# Altium schematic sheet LoRa.SchDoc(LoRa)
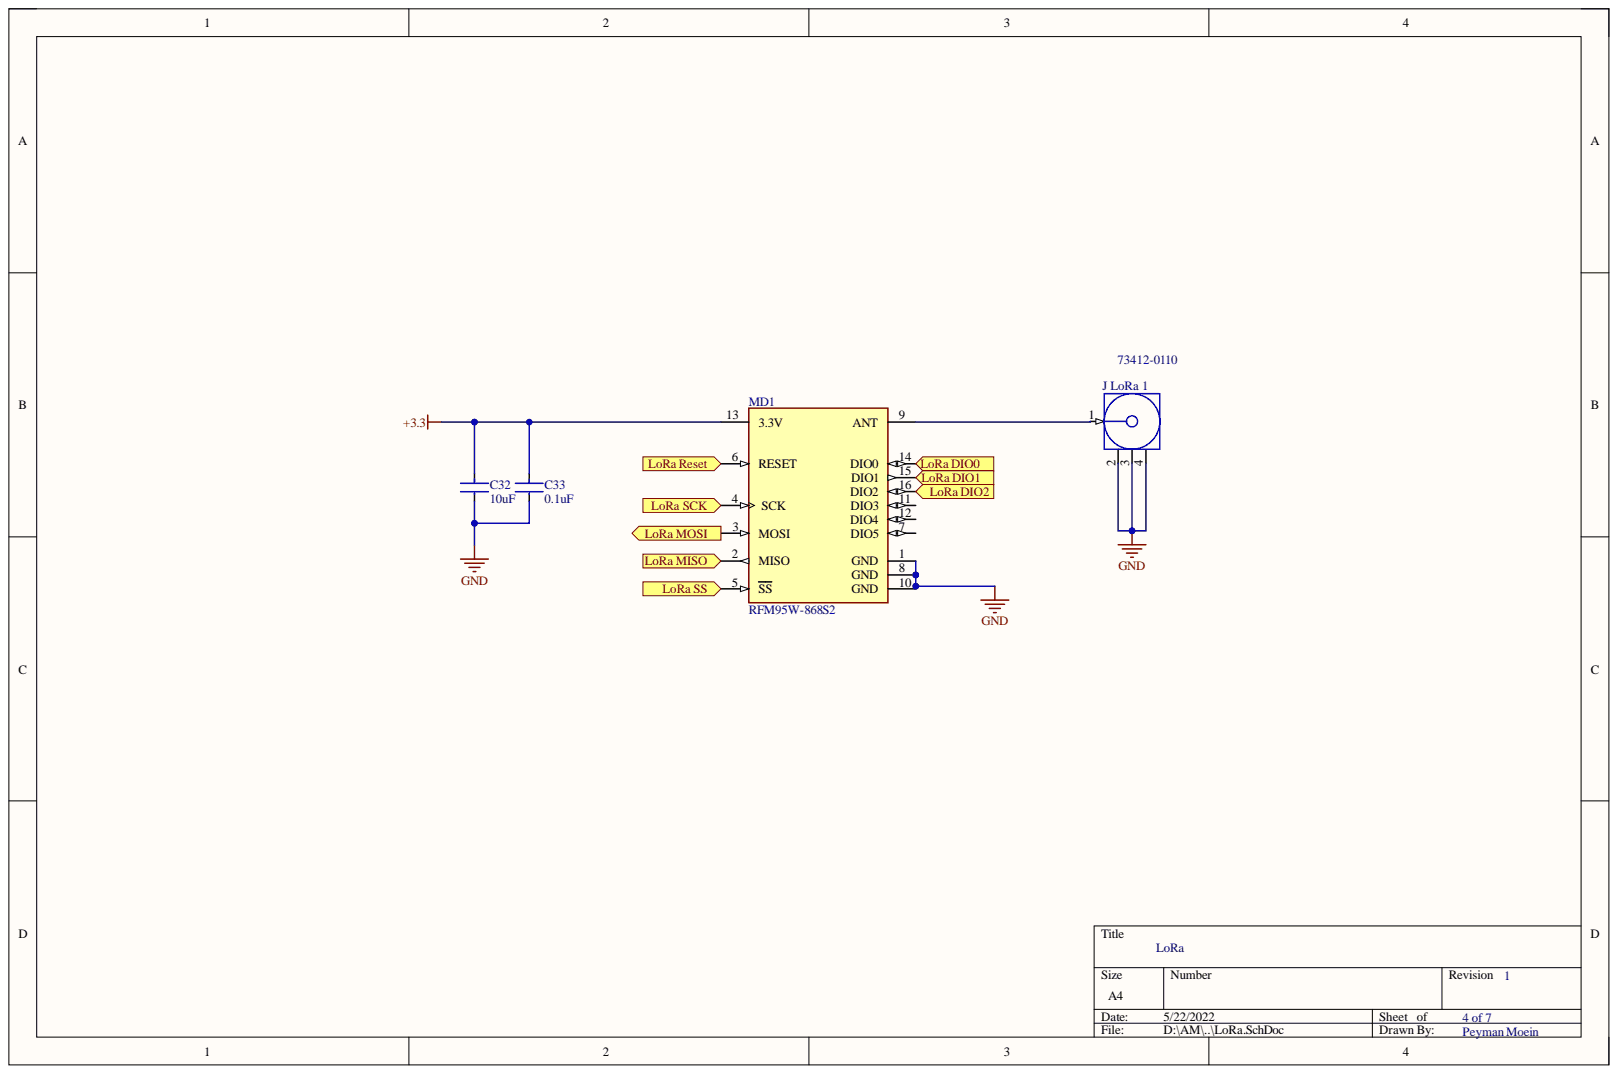
[diagram p0: LoRa.SchDoc]

## Parts
C32  10uF  [FP-GRM21B-0_1-IPC_C]
C33  0.1uF  [FP-C0805C-DN-MFG]
J_LoRa_1  73412-0110  [MOLX-73412-0110_V]
MD1  RFM95W-868S2  [FP-RFM95W-868S2-MFG]

## Ports
LoRa DIO0, LoRa DIO1, LoRa DIO2, LoRa MISO, LoRa MOSI, LoRa Reset, LoRa SCK, LoRa SS

## Nets
+3.3: C32.1 C33.1 MD1.13(3.3V)
GND: C32.2 C33.2 J_LoRa_1.2 J_LoRa_1.3 J_LoRa_1.4 MD1.1(GND) MD1.8(GND) MD1.10(GND)
LoRa DIO0: MD1.14(DIO0) ; port LoRa DIO0
LoRa DIO1: MD1.15(DIO1) ; port LoRa DIO1
LoRa DIO2: MD1.16(DIO2) ; port LoRa DIO2
LoRa MISO: MD1.2(MISO) ; port LoRa MISO
LoRa MOSI: MD1.3(MOSI) ; port LoRa MOSI
LoRa Reset: MD1.6(RESET) ; port LoRa Reset
LoRa SCK: MD1.4(SCK) ; port LoRa SCK
LoRa SS: MD1.5(SS) ; port LoRa SS
NetJ LoRa 1_1: J_LoRa_1.1 MD1.9(ANT)

Not connected: MD1 7(DIO5) 11(DIO3) 12(DIO4)

## Library data of the parts
C32 = CMP-2007-03453-2: Chip Multilayer Ceramic Capacitors for General Purpose, 0805, 10uF, X5R, 15%, 10%, 16V; Capacitance: 10uF; Case Code (Imperial): 0805; Case Code (Metric): 2012; Case/Package: 0805; Component Kind: Standard; Depth: 1.25mm; Dielectric: X5R; Height: 1.25mm; Lead Free: Lead Free; Length: 2mm; Library Name: Altium Content Vault; Material: Ceramic; Max Operating Temperature: 85°C; Min Operating Temperature: -55°C; Mount: Surface Mount; Package Quantity: 1; Packaging: Tape and Reel; Radiation Hardening: No; REACH SVHC: No SVHC; Termination: SMD/SMT; Thickness: 0.053inch; Tolerance: 10%; Voltage: 16V; Voltage Rating: 16V; Voltage Rating (DC): 16V; Width: 1.25mm
C33 = CMP-2007-00279-2: CAP CER 0.1UF 50V X7R 0805; Manufacturer: KEMET; Manufacturer Part Number: C0805C104K5RACTU; Capacitance: 0.10 μF; Material: Ceramic; Temperature Coefficient: 30ppm/°C; Tolerance: 0.1; Value: 0.10 μF; Automotive: No; Category: Cap; Component Kind: Standard; ComponentLink2Description: Datasheet; ComponentLink2URL: https://content.kemet.com/datasheets/KEM_C1003_C0G_SMD.pdf; Device Class L1: Passive Components; Device Class L2: Capacitors; Device Class L3: Ceramic Capacitors; Digikey Description: CAP CER 0.1UF 50V X7R 0805; Height: 0.88mm; Ipc Land Pattern Name: CAPC200125X78; Lead Free: Yes; Library Name: Altium Content Vault; Mouser Description: Cap Ceramic 0.1uF 50V X7R 10% SMD 2.0 x 1.2 mm 125 C Paper T/R; Octopart: https://octopart.com/c0805c104k5ractu-kemet-143926; Package: C0805C-DN; RoHS: TRUE; Temperature: -55°C to +125°C; Temperature Characteristic: X7R; Temperature Range High: +125°C; Temperature Range Low: -55°C; Voltage Rating: 50 V
J_LoRa_1 = CMP-1676-00003-1: MCRF, PCB Vertical Jack Receptacle, 50 Ohm, 4-Pin SMD, RoHS, Tape and Reel; Frequency: 6 Hz; Gender: Female; Impedance: 50 Ohm; Mating Cycles: 30; Voltage Rating: 60 V; Component Kind: Standard; ComponentLink1Description: Manufacturer URL; ComponentLink1URL: http://www.molex.com/; ComponentLink2Description: Datasheet; ComponentLink2URL: http://www.molex.com/webdocs/datasheets/pdf/en-us/0734120110_RF_COAX_CONNECTORS.pdf; ComponentLink3Description: Package Specification; ComponentLink3URL: http://www.molex.com/pdm_docs/sd/734120110_sd.pdf; Contact Material: Bronze; Contact Plating: Gold; DatasheetVersion: 04/2016; Height: 1.25 mm; Length: 3 mm; Library Name: Altium Content Vault; Max Operating Temperature: 90 degC; Min Operating Temperature: -40 degC; Mount: Surface Mount; Mounting Technology: Surface Mount; Package Quantity: 5000; PackageDescription: 4-Leads SMD, Body 2.6 x 2.6 mm; PackageReference: 73412-0110; PackageVersion: Rev. C14, 07/2015; Packaging: Tape and Reel; Radiation Hardening: No; REACH SVHC: Unknown; RoHSCompliant: true; Voltage Rating (AC): 60 V; Width: 3 mm
MD1 = CMP-204316-000001-1: RX TXRX MODULE ISM < 1GHZ SMD; Current - Receiving: 12.1mA; Current - Transmitting: 120mA; Data Rate: 300Kbps; Frequency: 868MHz; Interface: SPI; Sensitivity: -123dBm; Case/Package: SMD/SMT; Component Kind: Standard; Library Name: Altium Content Vault; Max Operating Temperature: 70°C; Min Operating Temperature: -20°C; Mount: Surface Mount; Packaging: Bulk
